# Altium schematic sheet LCD_PWR.SchDoc
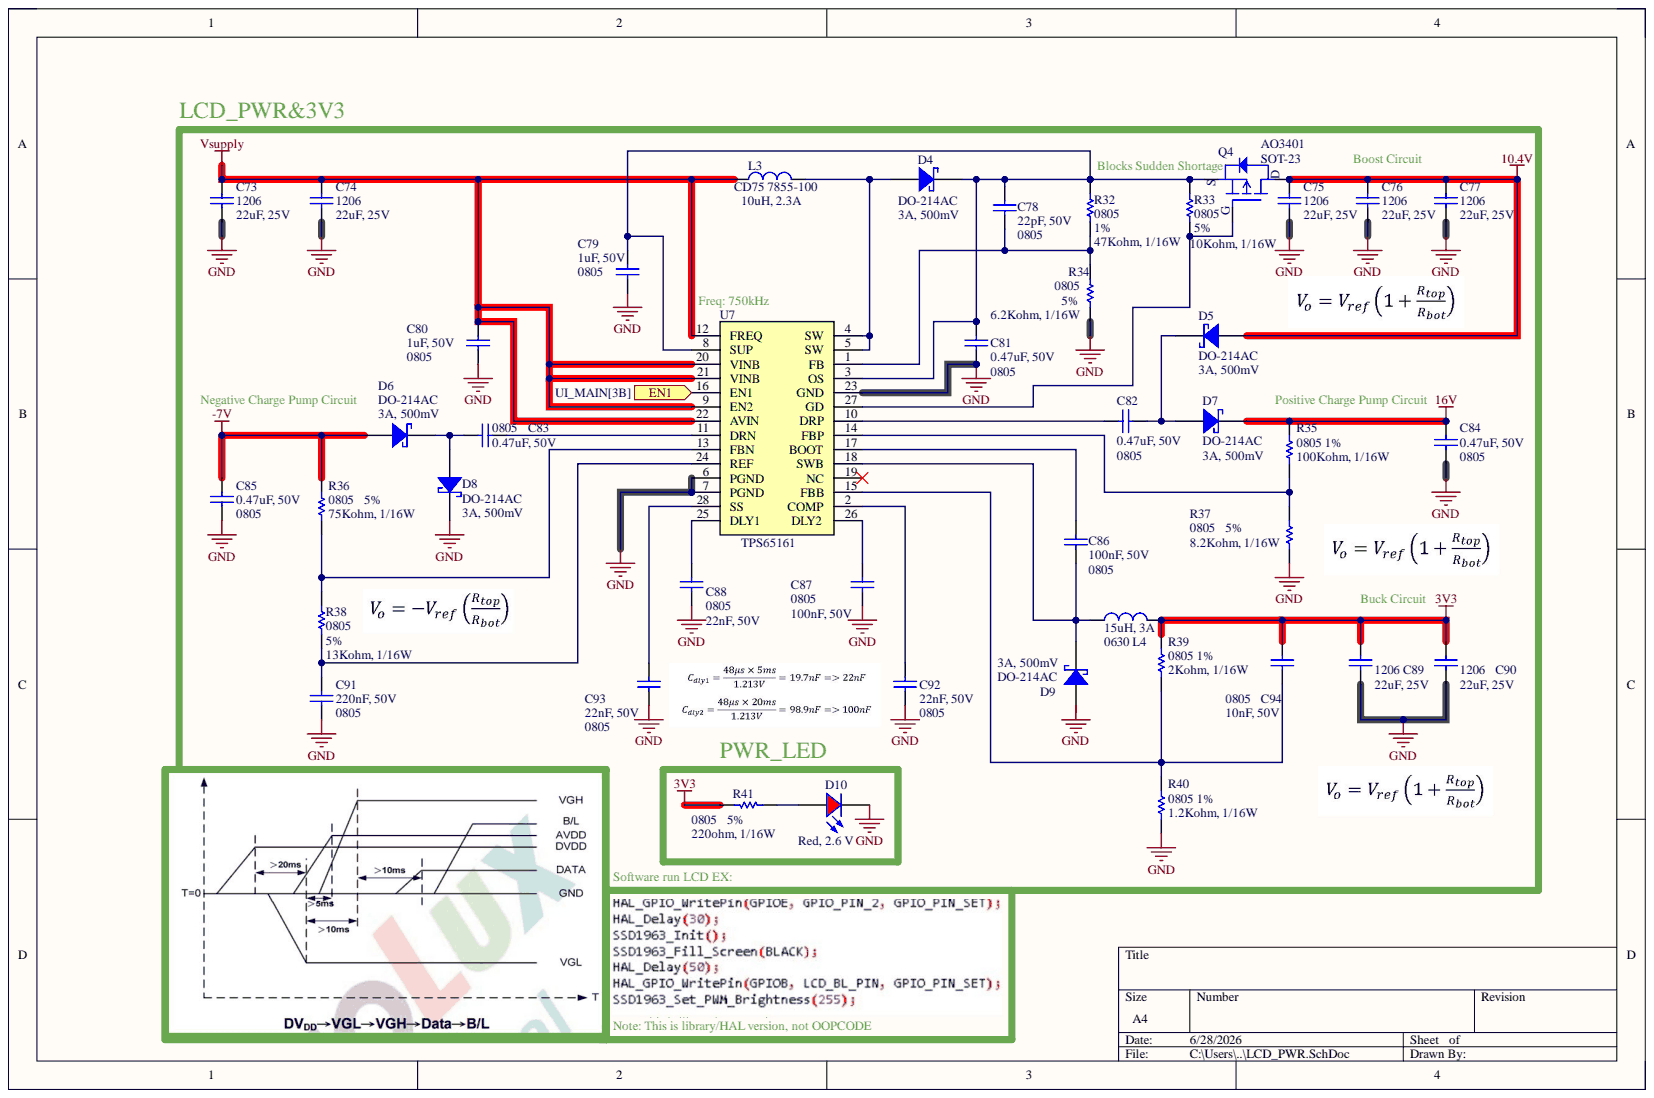
[diagram p0: LCD_PWR.SchDoc]

## Parts
C73  22uF, 25V  Package: 1206  [C_1206]
C74  22uF, 25V  Package: 1206  [C_1206]
C75  Package: 1206  [C_1206]  (hidden comment: 22uF, 25V)
C76  22uF, 25V  Package: 1206  [C_1206]
C77  22uF, 25V  Package: 1206  [C_1206]
C78  22pF, 50V  Package: 0805  [C_0805]
C79  1uF, 50V  Package: 0805  [C_0805]
C80  1uF, 50V  [C_0805]
C81  0.47uF, 50V  Package: 0805  [C_0805]
C82  0.47uF, 50V  Package: 0805  [C_0805]
C83  0.47uF, 50V  Package: 0805  [C_0805]
C84  0.47uF, 50V  Package: 0805  [C_0805]
C85  0.47uF, 50V  Package: 0805  [C_0805]
C86  100nF, 50V  Package: 0805  [C_0805]
C87  100nF, 50V  [C_0805]
C88  22nF, 50V  Package: 0805  [C_0805]
C89  22uF, 25V  Package: 1206  [C_1206]
C90  22uF, 25V  Package: 1206  [C_1206]
C91  220nF, 50V  Package: 0805  [C_0805]
C92  22nF, 50V  Package: 0805  [C_0805]
C93  22nF, 50V  [C_0805]
C94  10nF, 50V  Package: 0805  [C_0805]
D4  3A, 500mV  Package: DO-214AC  [PKG_SMA (DO-214AC)]
D5  3A, 500mV  Package: DO-214AC  [PKG_SMA (DO-214AC)]
D6  3A, 500mV  Package: DO-214AC  [PKG_SMA (DO-214AC)]
D7  3A, 500mV  Package: DO-214AC  [PKG_SMA (DO-214AC)]
D8  3A, 500mV  Package: DO-214AC  [PKG_SMA (DO-214AC)]
D9  3A, 500mV  Package: DO-214AC  [PKG_SMA (DO-214AC)]
D10  Red, 2.6 V  [LED_1206]
L3  10uH, 2.3A  Package: CD75 7855-100  [COIL_CDRH]
L4  value 15uH, 3A  Package: 0630  [COIL_74]  (hidden comment: I_CDRH)
Q4  AO3401  Package: SOT-23  [PKG_SOT-23]
R32  47Kohm, 1/16W  Acc: 1%  Package: 0805  [R_0805]
R33  10Kohm, 1/16W  Acc: 5%  Package: 0805  [R_0805]
R34  6.2Kohm, 1/16W  Acc: 5%  Package: 0805  [R_0805]
R35  100Kohm, 1/16W  Package: 0805  [R_0805]
R36  75Kohm, 1/16W  Package: 0805  [R_0805]
R37  8.2Kohm, 1/16W  [R_0805]
R38  13Kohm, 1/16W  Acc: 5%  Package: 0805  [R_0805]
R39  2Kohm, 1/16W  Package: 0805  [R_0805]
R40  1.2Kohm, 1/16W  Package: 0805  [R_0805]
R41  220ohm, 1/16W  Acc: 5%  Package: 0805  [R_0805]
U7  TPS65161  [PKG_HTSSOP-28]

## Ports
EN1

## Nets
3V3: C89.2 C90.2 C94.1 L4.2 R39.2 R41.2
10.4V: C75.2 C76.2 C77.2 D5.2 Q4.3
16V: C84.1 D7.1 R35.2
-7V: C85.1 D6.2 R36.2
GND: C73.1 C74.1 C75.1 C76.1 C77.1 C79.1 C80.1 C81.2 C84.2 C85.2 C87.2 C88.2 C89.1 C90.1 C91.2 C92.2 C93.2 D8.1 D10.1 R34.1 R37.1 R40.1 U7.6(PGND) U7.7(PGND) U7.23(GND)
Vsupply: C73.2 C74.2 C80.2 L3.1 U7.9(EN2) U7.12(FREQ) U7.20(VINB) U7.21(VINB) U7.22(AVIN)
EN1: U7.16(EN1) ; port EN1
NetC78_1: C78.1 C79.2 C81.1 D4.1 Q4.2 R32.2 R33.2 U7.3(OS) U7.8(SUP)
NetC78_2: C78.2 R32.1 R34.2 U7.1(FB)
NetC82_1: C82.1 U7.10(DRP)
NetC82_2: C82.2 D5.1 D7.2
NetC83_1: C83.1 U7.11(DRN)
NetC83_2: C83.2 D6.1 D8.2
NetC86_1: C86.1 U7.17(BOOT)
NetC86_2: C86.2 D9.1 L4.1 U7.18(SWB)
NetC87_1: C87.1 U7.26(DLY2)
NetC88_1: C88.1 U7.25(DLY1)
NetC91_1: C91.1 R38.2 U7.24(REF)
NetC92_1: C92.1 U7.2(COMP)
NetC93_1: C93.1 U7.28(SS)
NetC94_2: C94.2 R39.1 R40.2 U7.15(FBB)
NetD4_2: D4.2 L3.2 U7.4(SW) U7.5(SW)
NetD10_2: D10.2 R41.1
NetQ4_1: Q4.1 R33.1 U7.27(GD)
NetR35_1: R35.1 R37.2 U7.14(FBP)
NetR36_1: R36.1 R38.1 U7.13(FBN)

Not connected: D9 2; U7 19(NC)

## Library data of the parts
C73-C77 C89 C90 = CAP_1206: Manufacturer 1: Vishay; Manufacturer Part Number 1: CAP0805; Component Kind: Standard; Datasheet: https://www.mouser.de/datasheet/2/447/PYu_RC_Group_51_RoHS_L_11-1984063.pdf; Description: CAP_1206; Library Name: DisplayLib.IntLib; Supplier 1: Thegioiic; Supplier Link: N/a; Value: 22uF, 25V
C78-C88 C91-C94 = CAP_0805: Manufacturer 1: Vishay; Manufacturer Part Number 1: CAP0805; Component Kind: Standard; Datasheet: https://www.mouser.de/datasheet/2/447/PYu_RC_Group_51_RoHS_L_11-1984063.pdf; Description: CAP_0805; Library Name: DisplayLib.IntLib; Supplier 1: Thegioiic; Supplier Link: N/a
  C78: Value: 22pF, 50V
  C79: Value: 1uF, 50V
  C80: Package: 0805; Value: 1uF, 50V
  C81-C85: Value: 0.47uF, 50V
  C86: Value: 100nF, 50V
  C87: Package: 0805; Value: 100nF, 50V
  C88 C92: Value: 22nF, 50V
  C91: Value: 220nF, 50V
  C93: Package: 0805; Value: 22nF, 50V
  C94: Value: 10nF, 50V
D4-D9 = SCHOTTKY: SS34; Manufacturer 1: OEM; Manufacturer Part Number 1: N/a; Component Kind: Standard; ComponentLink1Description: Datasheet; ComponentLink1URL: https://www.vishay.com/doc?89055; Datasheet: N/a; Library Name: DisplayLib.IntLib; Supplier 1: Thegioiic; Supplier Link: N/a; Value: 3A, 500mV
D10 = RED: Red LED; Manufacturer 1: LITE-ON; Manufacturer Part Number 1: LEDR; Component Kind: Standard; ComponentLink1Description: Datasheet link; ComponentLink1URL: N/A; ComponentLink2Description: Supplier 1 URL; ComponentLink2URL: https://www.mouser.vn/ProductDetail/ROHM-Semiconductor/SML-H12U8TT86C?qs=HXFqYaX1Q2xs%2F%252BL6XLQEvw%3D%3D; ComponentLink3Description: Supplier 2 URL; ComponentLink3URL: https://www.digikey.com/en/products/detail/liteon/LTST-C171KRKT/386801; ComponentLink4Description: Supplier 3 URL; ComponentLink4URL: https://www.thegioiic.com/led-do-0805-dan-smd-trong-suot-harvatek; Datasheet: https://www.mouser.com/datasheet/2/239/LTST-S326KGKFKT-1143909.pdf?srsltid=AfmBOorgg6Gh4PD3T9FRO6Da906Aqdqx3RSjbUQRSIXh-3hATfgAoF8Q; Library Name: DisplayLib.IntLib; Package: 1206; Supplier 1: ICDAYROI; Supplier Link: https://icdayroi.com/led-smd-0805; Value: Red, 2.6 V
L3 = IN_CDRH: Coil Inductor SMD (standard); Manufacturer 1: OEM; Manufacturer Part Number 1: N/a; Component Kind: Standard; ComponentLink1Description: Datasheet; ComponentLink1URL: https://product.tdk.com/system/files/dam/doc/product/inductor/inductor/smd/catalog/inductor_automotive_decoupling_mlz1608_en.pdf?ref_disty=mouser; ComponentLink2Description: Supplier 1 URL; ComponentLink2URL: https://www.mouser.vn/ProductDetail/TDK/MLZ1608DR10DTD25?qs=u4%252BEJ9urDARQOELDKhLR2A%3D%3D; ComponentLink3Description: Supplier 2 URL; ComponentLink3URL: https://www.digikey.com/en/products/detail/tdk-corporation/MLZ1608DR10DTD25/4743170; ComponentLink4Description: Supplier 3 URL; Datasheet: N/a; Library Name: DisplayLib.IntLib; Supplier 1: Thegioiic; Supplier Link: N/a; Value: 10uH, 2.3A
L4 = IN_CD74: Coil Inductor SMD (array pad); Manufacturer 1: OEM; Manufacturer Part Number 1: N/a; Component Kind: Standard; ComponentLink1Description: Datasheet; ComponentLink1URL: https://product.tdk.com/system/files/dam/doc/product/inductor/inductor/smd/catalog/inductor_automotive_decoupling_mlz1608_en.pdf?ref_disty=mouser; ComponentLink2Description: Supplier 1 URL; ComponentLink2URL: https://www.mouser.vn/ProductDetail/TDK/MLZ1608M100WTD25?qs=u4%252BEJ9urDATpNhOvJ54DAQ%3D%3D; ComponentLink3Description: Supplier 2 URL; ComponentLink3URL: https://www.digikey.com/en/products/detail/tdk-corporation/MLZ1608M100WTD25/4743176; ComponentLink4Description: Supplier 3 URL; Datasheet: N/a; Library Name: DisplayLib.IntLib; Supplier 1: Thegioiic; Supplier Link: N/a
Q4 = AO3401: P-Channel 30 V 4A (Ta) 1.4W (Ta) Surface Mount SOT-23-3; Manufacturer 1: Alpha&Omega Semi.; Component Kind: Standard; Datasheet: https://www.snapeda.com/parts/AO3401/Alpha%20&%20Omega%20Semiconductor/datasheet/; Library Name: DisplayLib.IntLib; Manufacturer Part Number 1: AO3401; Supplier 1: ICDayroi; Supplier Link: https://icdayroi.com/ao3401
R32-R34 R38 R41 = RES_0805: Manufacturer 1: Vishay; Manufacturer Part Number 1: RES0805; Component Kind: Standard; Datasheet: https://www.mouser.de/datasheet/2/447/PYu_RC_Group_51_RoHS_L_11-1984063.pdf; Description: RES_0805; Library Name: DisplayLib.IntLib; Supplier 1: Thegioiic; Supplier Link: N/a
  R32: Value: 47Kohm, 1/16W
  R33: Value: 10Kohm, 1/16W
  R34: Value: 6.2Kohm, 1/16W
  R38: Value: 13Kohm, 1/16W
  R41: Value: 220ohm, 1/16W
R35 R39 R40 = RES_0805: Manufacturer 1: Vishay; Manufacturer Part Number 1: RES0805; Acc: 1%; Component Kind: Standard; Datasheet: https://www.mouser.de/datasheet/2/447/PYu_RC_Group_51_RoHS_L_11-1984063.pdf; Description: RES_0805; Library Name: DisplayLib.IntLib; Supplier 1: Thegioiic; Supplier Link: N/a
  R35: Value: 100Kohm, 1/16W
  R39: Value: 2Kohm, 1/16W
  R40: Value: 1.2Kohm, 1/16W
R36 R37 = RES_0805: Manufacturer 1: Vishay; Manufacturer Part Number 1: RES0805; Acc: 5%; Component Kind: Standard; Datasheet: https://www.mouser.de/datasheet/2/447/PYu_RC_Group_51_RoHS_L_11-1984063.pdf; Description: RES_0805; Library Name: DisplayLib.IntLib; Supplier 1: Thegioiic; Supplier Link: N/a
  R36: Value: 75Kohm, 1/16W
  R37: Package: 0805; Value: 8.2Kohm, 1/16W
U7 = TPS65161: BIAS POWER SUPPLY FOR TV AND MONITOR TFT LCD PANELS; Manufacturer 1: TI; Component Kind: Standard; Datasheet: https://www.ti.com/lit/ds/symlink/tps65161.pdf?ts=1780769383696&ref_url=https%253A%252F%252Fwww.google.com%252F; Library Name: DisplayLib.IntLib; Manufacturer Part Number 1: TPS65161; Supplier 1: shoppee; Supplier Link: https://shopee.vn/5-C%C3%81I-TPS65161PWPR-TSSOP28-TPS65161-TSSOP-28-TPS65161PW-TSSOP-TPS65161PWP-linh-ki%E1%BB%87n-%C4%91i%E1%BB%87n-t%E1%BB%AD-vanxy-i.1274879032.50207449630
